# Altium schematic sheet HAL_1.SchDoc
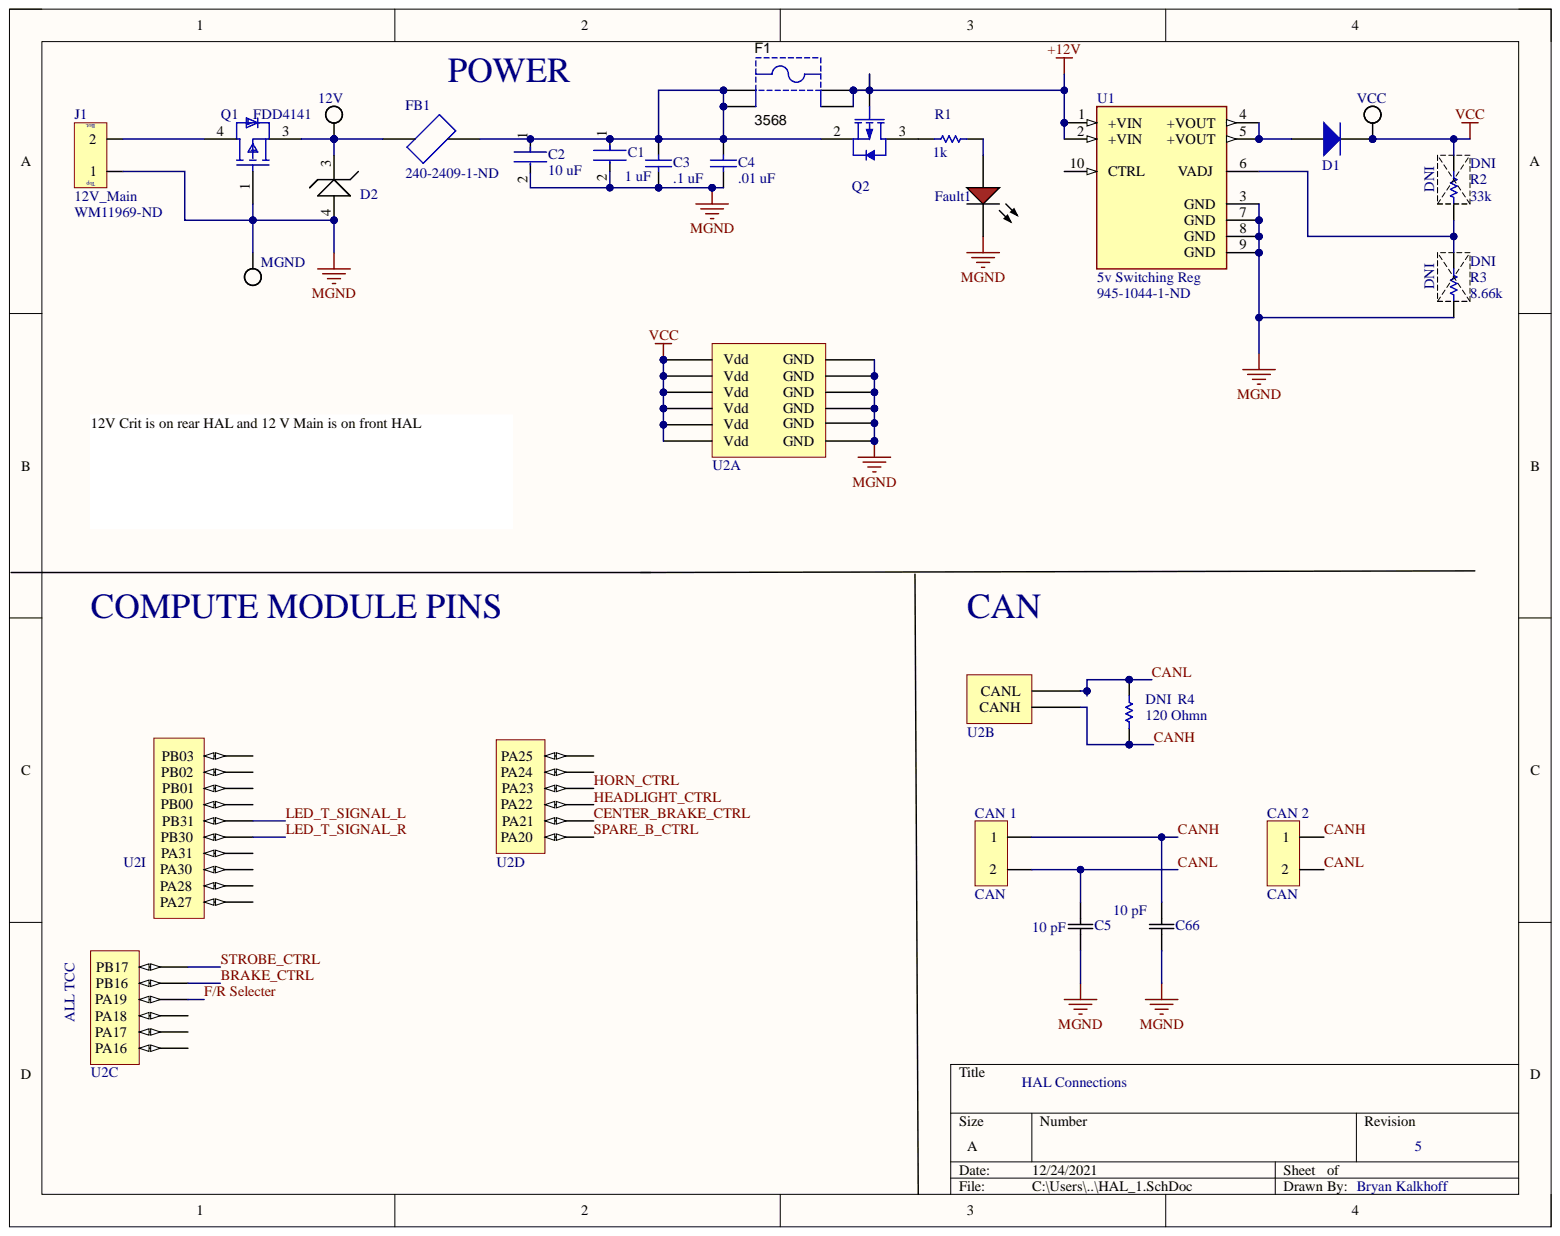
[diagram p0: HAL_1.SchDoc]

## Parts
12V  12V crit  [EPAD]  (value not drawn)  (no BOM)
C1  1 uF  [FP-CL31-IPC_A]
C2  10 uF  [FP-CL31-IPC_A]
C3  .1 uF  [CAPC2013X140X50LL20T25]
C4  .01 uF  [CAPC1608X90X35LL15T15]
C5  10 pF  [CAP_CL21_SAM-M]
C66  10 pF  [CAP_CL21_SAM-M]
CAN_1  CAN  [Mini-Fit Jr. 2]
CAN_2  CAN  [Mini-Fit Jr. 2]
D1  1N4001-T  [DIOD-DO-41-P-2_V]  (value not drawn)
D2  TVS DIODE 12.4V 20.1V DO219AB  [TVS DIODE 12.4V 20.1V DO219AB]  (value not drawn)
F1  3568  [KSTN-3568_V]
Fault1  HSMH-C150  [AVAG-HSMX-C150_V]  (value not drawn)
FB1  Digi-Key_Part_Number: 240-2409-1-ND  [80_FB_1206]  (hidden comment: HI1206N800R-10)
J1  12V_Main  DigiKey Part Number: WM11969-ND  [MEGA_2P_2x1]
MGND  MGND  [EPAD]  (no BOM)
Q1  FDD4141  [FP-369AS-MFG]
Q2  IRLML6402TRPBF  [INTR-SOT-23-3_V]  (value not drawn)
R1  1k  [RESC3216X70X40LL20T20]
R2  33k  [RESC2013X50X30LL20T20]
R3  8.66k  [RESC2013X60X35ML10T20]
R4  120 Ohmn  [RESC2013X50X40LL20T20]
U1  5v Switching Reg  Digi-Key_Part_Number: 945-1044-1-ND  [10-SMD]
U2  S+  [Compute S+]  (parts A,B,C,D,I)
VCC  VCC  [EPAD]  (no BOM)

## Nets
+12V: F1.3 F1.4 Q2.1 U1.1(+VIN) U1.2(+VIN)
MGND: C1.2 C2.2 C3.1 C4.1 C5.1 C66.1 D2.4 Fault1.2 J1.1 MGND.1 Q1.1 R3.1 U1.3(GND) U1.7(GND) U1.8(GND) U1.9(GND) U2.GND
VCC: D1.2 R2.2 U2.Vdd VCC.1
BRAKE_CTRL: U2.PB16
CANH: C66.2 CAN_1.1 CAN_2.1 R4.2 U2.CANH
CANL: C5.2 CAN_1.2 CAN_2.2 R4.1 U2.CANL
CENTER_BRAKE_CTRL: U2.PA21
F/R Selecter: U2.PA19
HEADLIGHT_CTRL: U2.PA22
HORN_CTRL: U2.PA23
LED_T_SIGNAL_L: U2.PB31
LED_T_SIGNAL_R: U2.PB30
SPARE_B_CTRL: U2.PA20
STROBE_CTRL: U2.PB17
Net12V_1: 12V.1 D2.3 FB1.1 Q1.3
NetC1_1: C1.1 C2.1 C3.2 C4.2 F1.1 F1.2 FB1.2 Q2.2
NetD1_1: D1.1 U1.4(+VOUT) U1.5(+VOUT)
NetFault1_1: Fault1.1 R1.2
NetJ1_2: J1.2 Q1.4
NetQ2_3: Q2.3 R1.1
NetR2_1: R2.1 R3.2 U1.6(VADJ)

Not connected: U1 10(CTRL); U2 PA16 PA17 PA18 PA24 PA25 PA27 PA28 PA30 PA31 PB00 PB01 PB02 PB03

## Library data of the parts
12V MGND VCC = EPAD: Pad for probing signals; Library Name: PrISUm-Discrete.SchLib
C1 C2 = CMP-13271-002819-1: Cap Ceramic 10uF 16V X5R ±10% SMD 1206 +85°C Embossed T/R; Capacitance: 10uF; Case Code (Imperial): 1206; Case Code (Metric): 3216; Case/Package: 1206; Component Kind: Standard; Contact Plating: Tin; Depth: 1.6mm; Dielectric: X5R; Height: 1.6mm; Lead Free: Lead Free; Length: 3.2mm; Library Name: Altium Content Vault; Material: Ceramic; Max Operating Temperature: 85°C; Min Operating Temperature: -55°C; Mount: Surface Mount; Packaging: Tape and Reel; Radiation Hardening: No; Thickness: 0.071inch; Tolerance: 10%; Voltage: 16V; Voltage Rating: 16V; Voltage Rating (DC): 16V; Width: 0.063inch
C3 = CMP-2007-04740-1: Manufacturer: AVX Interconnect / Elco; Part Number: 08051C104M4Z2A; Component Kind: Standard; Library Name: Altium Content Vault
C4 = CMP-2006-04438-1: Manufacturer: AVX Interconnect / Elco; Part Number: 06035C472K4T4A; Component Kind: Standard; Library Name: Altium Content Vault
C5 C66 = CL21C100CBANNNC: No Description Available; Mfr_Name: Samsung; Type: DEV; Component Kind: Standard; Digi-Key_Part_Number_1: 1276-2561-2-ND; Digi-Key_Part_Number_2: 1276-2561-1-ND; Digi-Key_Part_Number_3: 1276-2561-6-ND; Library Name: CL21C100CBANNNC.SchLib; Manufacturer_Part_Number: CL21C100CBANNNC; RefDes: RefDes; Copyright: Copyright (C) 2021 Ultra Librarian. All rights reserved.
CAN_1 CAN_2 = Mini-Fit Jr. 2-pin: Mini-Fit Jr. 2-pin Right Angle Mini-Fit Jr. 2-pin; Manufacturer: Molex Inc; Manufacturer Part Number: 0039300020; Connector Type: Header, Shrouded; Number of Positions: 2; Number of Positions Loaded: All; Row Spacing: 0.165" (4.20mm); Category: Connectors, Interconnects; Color: Natural; Component Kind: Standard; ComponentLink1Description: http://www.molex.com/pdm_docs/sd/039300020_sd.pdf; ComponentLink1URL: http://www.molex.com/pdm_docs/sd/039300020_sd.pdf; Contact Finish: Tin; Contact Finish Thickness: 200µin (5.08µm); Contact Mating Length: -; Contact Type: Male Pin; Datasheet: http://www.molex.com/pdm_docs/sd/039301020_sd.pdf; Fastening Type: Locking Ramp; Features: Board Lock; Library Name: PrISUm-Connectors.SchLib; Mounting Type: Through Hole, Right Angle; Number of Rows: 2; Ordering: http://search.digikey.com/scripts/DkSearch/dksus.dll?Detail&name=WM1351-ND; Packaging: Tray; Pitch: -; Pricing 1: 1=0.77, 10=0.674, 50=0.6078, 100=0.5815, 250=0.5286, 500=0.47574, 1000=0.42288, 2500=0.38324, 5000=0.37002 (USD); RoHS: RoHS Compliant; Stock 1: 371; Supplier 1: Digi-Key; Termination: Solder
D1 = CMP-0639-00006-2: 1.0 A Rectifier, 15 pF, 50 V, -65 to 150 degC, 2-Pin DO-41 Plastic, RoHS, Tape and Reel; Manufacturer: Diodes Inc; CT (pF): 15; Max. Average Rectified Current IO (A): 1; Max. IFSM (A): 30; Max. IR (uA): 5; VRRM (V): 50; Component Kind: Standard; ComponentLink1Description: Manufacturer URL; ComponentLink1URL: http://diodes.com/; ComponentLink2Description: Datasheet; ComponentLink2URL: http://diodes.com/datasheets/ds28002.pdf; DatasheetVersion: Rev. 8-2; Library Name: Altium Content Vault; Mounting Technology: Through Hole; Operating Temperature Range (degC): -65 to 150; PackageDescription: 2-Pin Axial Lead, Body 4.635 x 2.36 mm, Lead Spread 25.4 mm; PackageReference: DO-41-P-2; Packing: Tape and Reel; PartNumber: 1N4001-T; RoHS: TRUE; VF (V): 1
F1 = CMP-2000-05464-1: Fuse Holder, 20 A, 500 V, -50 to 145 degC, 4-Pin THD, RoHS; Manufacturer: Keystone; Component Kind: Standard; Datasheet URL: https://datasheet.ciiva.com/16294/m60-2p40-16294706.pdf; Datasheet Version: 04/2013; Library Name: Altium Content Vault; Manufacturer URL: http://www.keyelco.com/; Mounting Technology: ThroughHole; Package Description: 4-Pin Through Hole Device, Body 16 x 6.73 mm, Pitch 9.9 mm; Package Reference: 3568
Fault1 = CMP-2000-06426-1: LED Uni-Color Red, 65 mW, 25 mA, -40 to 85 degC, 2-Pin SMD, RoHS, Tape and Reel; Manufacturer: Avago; Chip Material: GaAlAs; DELETED: Compliant; Dominant Wave Length: 639nm; Forward Current: 25mA; Forward Voltage: 2.6V; High Brightness: No; Lens Type: ColorlessDiffused; Lum Intensity: 17mcd; Main Category: ChipLED; Number of  Elements: 1; Peak Wavelength: 660nm; Power Dissipation: 78W; Product Depth (mm): 1.6mm; Product Height (mm): 1.1mm; Product Length (mm): 3.2mm; Reverse Voltage: 5V; Shape Type: Rectangular; Test Current (It): 20mA; Viewing Angle: 170deg; Component Kind: Standard; Datasheet URL: http://media.digikey.com/pdf/Data%20Sheets/Avago%20PDFs/HSMz-Czzz.pdf; Datasheet Version: 03/2012; Emitting Color: Red; Lens Dimensions: 2x1.6x0.6mm; Library Name: Altium Content Vault; Luminous Intensity: 17mcd; Manufacturer URL: http://www.avagotech.com/; Mounting: SurfaceMount; Mounting Technology: SurfaceMount; Operating Temp Range: -40Cto85C; Operating Temperature Classification: Industrial; Package Description: 2-Pin Surface Mount Device, Body 3.2 x 1.6 mm; Package Reference: HSMX-C150; Package Type: ChipLed; Packaging: TapeandReel; Pin Count: 2; Rad Hardened: No; Type: Uni-Color
FB1 = 80_FB_1206: Ferrite Chip, 1 Function(s), 3A, 2 Pin(s); Manufacturer: Laird Steward; Manufacturer Series: HI; DC Resistance-Max: 35mR; Max Frequency: 100MHz; MF: Laird-Signal Integrity; Number of Functions: 1; Output Impedance: 80R; Value: 80R; Case Code: 1206; Category: Fixed Inductors; Check_prices: https://www.snapeda.com/parts/HI1206N800R-10/Laird-Signal%20Integrity%20Products/view-part/296889/?ref=eda; Component Kind: Standard; ComponentLink1Description: https://datasheet.ciiva.com:443/pdfs/VipMasterIC/IC/STWD/STWDS00332/STWDS00332-1.pdf?src-supplier=IHS+Markit; ComponentLink1URL: https://datasheet.ciiva.com:443/pdfs/VipMasterIC/IC/STWD/STWDS00332/STWDS00332-1.pdf?src-supplier=IHS+Markit; ComponentLink2Description: https://datasheet.ciiva.com/3081/hi1206n800r-10-a-vp-3081809.pdf?src-supplier=Avnet; ComponentLink2URL: https://datasheet.ciiva.com/3081/hi1206n800r-10-a-vp-3081809.pdf?src-supplier=Avnet; ComponentLink3Description: https://datasheet.ciiva.com/22622/1685745-22622768.pdf?src-supplier=Element14; ComponentLink3URL: https://datasheet.ciiva.com/22622/1685745-22622768.pdf?src-supplier=Element14; ComponentLink4Description: https://datasheet.ciiva.com/16714/70065439-16714107.pdf?src-supplier=Allied+Electronics; ComponentLink4URL: https://datasheet.ciiva.com/16714/70065439-16714107.pdf?src-supplier=Allied+Electronics; Construction: Chip Bead; Current Rating: 3A; Filter Type: FERRITE CHIP; Height: 1.1mm; JESD-609 Code: e3; Length: 3.2mm; Library Name: Resistors1.SchLib; Manufacturer Part Number: HI1206N800R-10; Material: Ferrite; Max Operating Temperature: 125°C; Min Frequency: 100MHz; Min Operating Temperature: -55°C; Mount: Surface Mount; MP: HI1206N800R-10; Package: 1206 Laird-Signal Integrity; Packaging: Tape and Reel; Pricing 1: 1=0.23000, 10=0.18500, 25=0.14400, 50=0.11920, 100=0.10280, 250=0.08220, 500=0.07400, 1000=0.06166 (USD); Purchase-URL: https://pricing.snapeda.com/search/part/HI1206N800R-10/?ref=eda; REACH SVHC: No SVHC; RoHS: Yes; RoHS Compliant: Yes; Series: HI1206N800R; SnapEDA_Link: https://www.snapeda.com/parts/HI1206N800R-10/Laird-Signal%20Integrity%20Products/view-part/296889/?ref=snap; Stock 1: 9365; Supplier 1: Digi-Key; Supplier Part Number 1: 240-2409-1-ND; Terminal Finish: Nickel; Width: 1.6mm
J1 = MEGA_2P_2x1: MOLEX - 76825-0002 - Wire-To-Board Connector, 2 Contacts, Header, Mega-Fit 76825 Series, Through Hole, 2 Rows; Manufacturer Part Number: 0768250002; SchematicInformation: MegaFit_2P; Category: Headers and Wire Housings; Component Kind: Standard; ComponentLink1Description: http://www.farnell.com/datasheets/2216551.pdf?src-supplier=Farnell; ComponentLink1URL: http://www.farnell.com/datasheets/2216551.pdf?src-supplier=Farnell; ComponentLink2Description: https://www.molex.com/pdm_docs/sd/768250002_sd.pdf?src-supplier=Digi-Key; ComponentLink2URL: https://www.molex.com/pdm_docs/sd/768250002_sd.pdf?src-supplier=Digi-Key; ComponentLink3Description: http://www.farnell.com/datasheets/2216551.pdf?src-supplier=Newark; ComponentLink3URL: http://www.farnell.com/datasheets/2216551.pdf?src-supplier=Newark; Library Name: Connectors.SchLib; Manufacturer: Molex; Pricing 1: 1=1.31000, 10=1.08200, 80=0.90150, 1850=0.67615, 3700=0.65361 (USD); RoHS: Yes; Stock 1: 16040; Supplier 1: Digi-Key; Supplier Part Number 1: WM11969-ND
Q1 = CMP-07173-000088-1: MOSFET P-CH 40V 10.8A DPAK; Manufacturer: ON Semiconductor; Continuous Drain Current: -10.8A; Depletion Mode: False; Drain To Source Breakdown Voltage: -40V; Drain To Source Resistance: 10.1mΩ; Gate Charge At Vgs: 36nC @ -10V; Gate To Source Voltage: 20V; Input Capacitace At Vds: 2085pF @ -20V; Max Forward Diode Voltage: -1.2V; Max Junction Temp: +150°C; Number Of Channels: 1; Power Dissipation: 2.4W; Pulse Drain Current: -100A; Reverse Recovery Charge: 26nC; Reverse Recovery Time: 29ns; Rthja Max: 52°C/W; Threshold Vgs Max: -3V; Threshold Vgs Min: -1V; Transconductance: 38S; Turn Off Delay Time: 38ns; Turn On Delay Time: 10ns; Typ Forward Diode Voltage: -0.8V; Automotive: No; Category: Diode; Component Kind: Standard; ComponentLink2Description: Datasheet; ComponentLink2URL: https://www.onsemi.com/pub/Collateral/FDD4141-D.pdf; Device Class L1: Discrete Semiconductors; Device Class L2: Transistors; Device Class L3: MOSFETs; Digikey Description: MOSFET P-CH 40V 10.8A DPAK; Drain To Source Voltage: -40V; Footprint Url: https://www.onsemi.com/pub/Collateral/369AS.PDF; Height: 2.517mm; Lead Free: Yes; Library Name: Altium Content Vault; Manufacturer Part Number: FDD4141; Mouser Description: MOSFET -40V P-Channel PowerTrench; Number Of P Channels: 1; Octopart: https://octopart.com/fdd4141-on+semiconductor-84325802; Package: 369AS; RoHS: TRUE; Temperature: -55°C to +150°C; Temperature Range High: +150°C; Temperature Range Low: -55°C
Q2 = CMP-2000-04987-1: HEXFET(R) Power P-Channel MOSFET, -20 V, -3.7 A, -55 to 150 degC, 3-Pin SOT-23, RoHS, Tape and Reel; Manufacturer: International Rectifier; Drain-Source On Resistance-Max: 0.065O; Drain-to-Source Voltage [Vdss]: 20V; Qg Gate Charge: 8nC; Rated Power Dissipation: 1.3W; Channel Type: P-Channel; Component Kind: Standard; Datasheet URL: http://datasheet.octopart.com/IRLML6402TRPBF-International-Rectifier-datasheet-93882.pdf; Datasheet Version: Feb-05; Library Name: Altium Content Vault; Manufacturer URL: http://internationalrectifier.com/; Package Description: 3-Pin Small Outline Transistor, Body 2.92 x 1.3 mm, Pitch 0.95 mm; Package Reference: SOT-23-3
R1 = CMP-2003-04517-1: RK73B2BTTD102J; Manufacturer: KOA Speer; Component Kind: Standard; Library Name: Altium Content Vault; Part Number: RK73B2BTTD102J
R2 = CMP-2001-03828-1: CRCW080533K0JNEA; Manufacturer: Vishay Dale; Component Kind: Standard; Library Name: Altium Content Vault; Part Number: CRCW080533K0JNEA
R3 = CMP-2001-01296-1: RC0805FR-078K66L; Manufacturer: Yageo; Component Kind: Standard; Library Name: Altium Content Vault; Part Number: RC0805FR-078K66L
R4 = CMP-2001-03236-1: RR1220P-121-D; Manufacturer: Susumu; Component Kind: Standard; Library Name: Altium Content Vault; Part Number: RR1220P-121-D
U1 = 18/6.5V_5V_1A_SWITCH_10-SMD: DC-DC Regulated Power Supply Module, 1 Output, 5W, Hybrid; Manufacturer: Recom; Manufacturer Part Number: R-78AA5.0-1.0SMD-R; Max Input Voltage: 18V; Max Output Current: 1A; MF: Recom Power; Min Input Voltage: 6.5V; Package Body Material: Plastic; Qualification Status: Not Qualified; Analog IC - Other Type: DC-DC REGULATED POWER SUPPLY MODULE; BuyPart: https://www.snapeda.com/parts/R-78AA5.0-1.0SMD-R/Recom%20Power/view-part/480105/?ref=eda; Category: Voltage Regulators - Switching; Component Kind: Standard; ComponentLink1Description: https://datasheet.ciiva.com:443/pdfs/VipMasterIC/IC/RECG/RECG-S-A0007788603/RECG-S-A0007788603-1.pdf?src-supplier=IHS+Markit; ComponentLink1URL: https://datasheet.ciiva.com:443/pdfs/VipMasterIC/IC/RECG/RECG-S-A0007788603/RECG-S-A0007788603-1.pdf?src-supplier=IHS+Markit; ComponentLink2Description: https://datasheet.ciiva.com/2374/r-78aaxx-1-0smd-2374214.pdf?src-supplier=Digi-Key; ComponentLink2URL: https://datasheet.ciiva.com/2374/r-78aaxx-1-0smd-2374214.pdf?src-supplier=Digi-Key; ComponentLink3Description: https://datasheet.ciiva.com/2374/r-78aaxx-1-0smd-2374215.pdf?src-supplier=Digi-Key; ComponentLink3URL: https://datasheet.ciiva.com/2374/r-78aaxx-1-0smd-2374215.pdf?src-supplier=Digi-Key; ComponentLink4Description: https://datasheet.ciiva.com/2374/r-78aaxx-1-0smd-2374216.pdf?src-supplier=Digi-Key; ComponentLink4URL: https://datasheet.ciiva.com/2374/r-78aaxx-1-0smd-2374216.pdf?src-supplier=Digi-Key; DigiKey: 945-1044-2-ND; DigiKey-Purchase-URL: https://snapeda.com/shop?store=DigiKey&id=480105; Library Name: Switching Regulators.SchLib; Max Operating Temperature: 85°C; Min Operating Temperature: -40°C; Mouser-Purchase-URL: https://snapeda.com/shop?store=Mouser&id=480105; MP: R-78AA5.0-1.0SMD-R; Nominal Output Voltage: 5V; Number of Pins: 10; Package: SMD-10 Recom Power; Package Code: SOP; Package Shape: Rectangular; Package Style: SMALL OUTLINEMeter; Pricing 1: 1=9.84000, 5=9.75800, 10=9.68300, 25=9.53440, 50=9.23640, 100=8.43000 (USD); REACH SVHC: Yes; RoHS: Yes; RoHS Compliant: Yes; SnapEDA_Link: https://www.snapeda.com/parts/R-78AA5.0-1.0SMD-R/Recom%20Power/view-part/480105/?ref=snap; Stock 1: 5089; Supplier 1: Digi-Key; Supplier Part Number 1: 945-1044-1-ND; Surface Mount: Yes; Temperature Grade: INDUSTRIAL; Terminal Form: Gull Wing; Terminal Pitch: 2.54mm; Terminal Position: DUAL
U2 = S+: Need to get 4 of PPPC161LFBN-RC; Component Kind: Standard; datasheet: https://media.digikey.com/pdf/Data%20Sheets/Sullins%20PDFs/Female_Headers.100_DS.pdf; Digi-key: https://www.digikey.com/product-detail/en/sullins-connector-solutions/PPPC161LFBN-RC/S7049-ND/810188; Library Name: Miscellaneous.SchLib
D2 = TVS DIODE 12.4V 20.1V DO219AB: Component Kind: Standard; ComponentLink1Description: Digikey; ComponentLink1URL: https://www.digikey.com/product-detail/en/vishay-semiconductor-diodes-division/VTVS12ASMF-M3-08/112-VTVS12ASMF-M3-08CT-ND/7055981; ComponentLink2Description: Datasheet; ComponentLink2URL: http://www.vishay.com/docs/85891/vtvs3v3asmf.pdf; Library Name: HAL and MotorBoard.SchLib
